# Altium schematic sheet A2-ESP.SchDoc
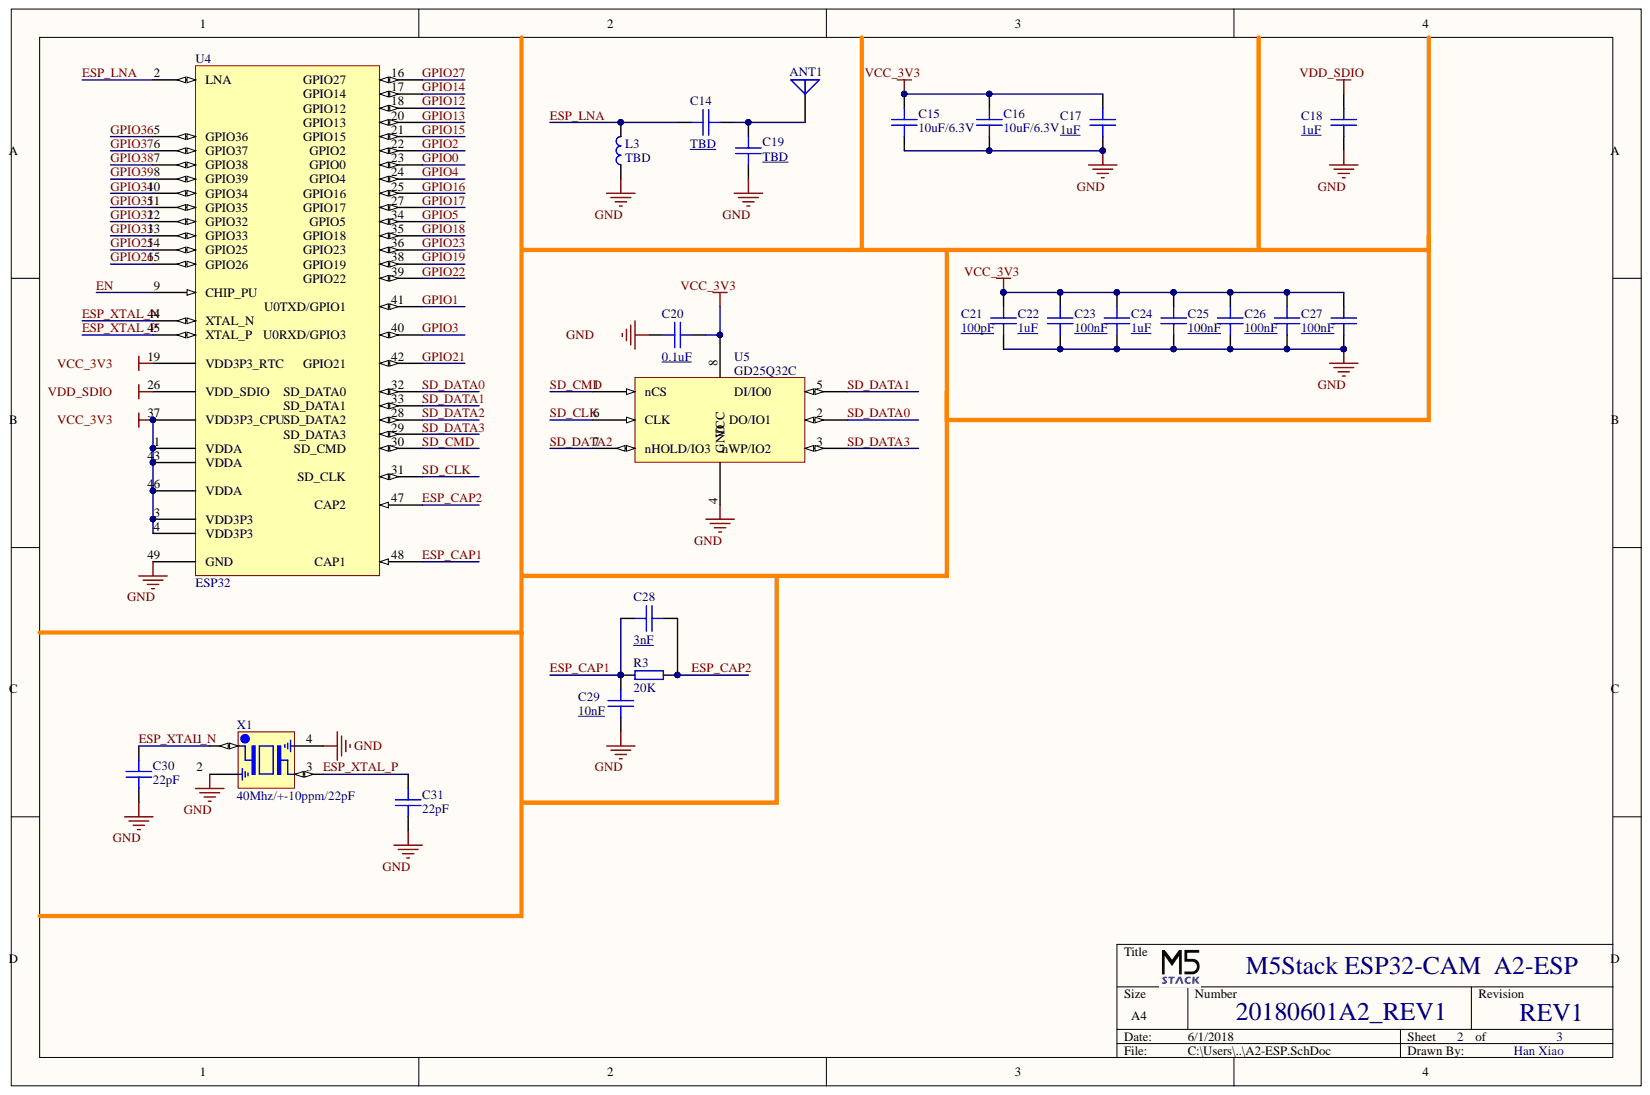
[diagram p0: A2-ESP.SchDoc]

## Parts
ANT1  Antenna  [Antenna_IIFA_2.4GHz_ESP]  (value not drawn)
C14  TBD  [SMT_C_0402]
C15  10uF/6.3V  [SMT_C_0603]
C16  10uF/6.3V  [SMT_C_0603]
C17  1uF  [SMT_C_0402]
C18  1uF  [SMT_C_0402]
C19  TBD  [SMT_C_0402]
C20  0.1uF  [SMT_C_0402]
C21  100pF  [SMT_C_0402]
C22  1uF  [SMT_C_0402]
C23  100nF  [SMT_C_0402]
C24  1uF  [SMT_C_0402]
C25  100nF  [SMT_C_0402]
C26  100nF  [SMT_C_0402]
C27  100nF  [SMT_C_0402]
C28  3nF  [SMT_C_0402]
C29  10nF  [SMT_C_0402]
C30  22pF  [SMT_C_0402]
C31  22pF  [SMT_C_0402]
L3  TBD  [SMT_L_0402]
R3  20K  [SMT_R_0402]
U4  ESP32  [PQFN40P600X600X90_HS-49N]
U5  GD25Q32C  [WSOP-8]
X1  40Mhz/+-10ppm/22pF  [SMT_XTAL_2520]

## Nets
GND: C15.2 C16.2 C17.1 C18.1 C19.1 C20.1 C21.1 C22.1 C23.1 C24.1 C25.1 C26.1 C27.1 C29.1 C30.2 C31.2 L3.2 U4.49(GND) U5.4(GND) X1.2 X1.4
VCC_3V3: C15.1 C16.1 C17.2 C20.2 C21.2 C22.2 C23.2 C24.2 C25.2 C26.2 C27.2 U4.1(VDDA) U4.3(VDD3P3) U4.4(VDD3P3) U4.19(VDD3P3_RTC) U4.37(VDD3P3_CPU) U4.43(VDDA) U4.46(VDDA) U5.8
VDD_SDIO: C18.2 U4.26(VDD_SDIO)
EN: U4.9(CHIP_PU)
ESP_CAP1: C28.1 C29.2 R3.1 U4.48
ESP_CAP2: C28.2 R3.2 U4.47
ESP_LNA: C14.1 L3.1 U4.2(LNA)
ESP_XTAL_N: C30.1 U4.44(XTAL_N) X1.1
ESP_XTAL_P: C31.1 U4.45(XTAL_P) X1.3
GPIO0: U4.23
GPIO1: U4.41
GPIO2: U4.22
GPIO3: U4.40
GPIO4: U4.24
GPIO5: U4.34
GPIO12: U4.18
GPIO13: U4.20
GPIO14: U4.17
GPIO15: U4.21
GPIO16: U4.25
GPIO17: U4.27
GPIO18: U4.35
GPIO19: U4.38
GPIO21: U4.42
GPIO22: U4.39
GPIO23: U4.36
GPIO25: U4.14(GPIO25)
GPIO26: U4.15(GPIO26)
GPIO27: U4.16
GPIO32: U4.12(GPIO32)
GPIO33: U4.13(GPIO33)
GPIO34: U4.10(GPIO34)
GPIO35: U4.11(GPIO35)
GPIO36: U4.5(GPIO36)
GPIO37: U4.6(GPIO37)
GPIO38: U4.7(GPIO38)
GPIO39: U4.8(GPIO39)
SD_CLK: U4.31 U5.6(CLK)
SD_CMD: U4.30 U5.1(nCS)
SD_DATA0: U4.32 U5.2
SD_DATA1: U4.33 U5.5
SD_DATA2: U4.28 U5.7(nHOLD/IO3)
SD_DATA3: U4.29 U5.3
NetANT1_1: ANT1.1 C14.2 C19.2

## Library data of the parts
ANT1 = Antenna: Generic Antenna; Component Kind: Standard; Library Name: Vowstar_Antenna.IntLib
C14 C17-C31 = SMT_C_0402: Surface mount capacitor 0402; Component Kind: Standard; Library Name: Vowstar_Basic_Device.IntLib
C15 C16 = SMT_C_0603: Surface mount capacitor 0603; Component Kind: Standard; Library Name: Vowstar_Basic_Device.IntLib
L3 = SMT_L_0402: Surface mount inductor 0402; Component Kind: Standard; Library Name: Vowstar_Basic_Device.IntLib
R3 = SMT_R_0402: Surface mount resistor 0402; Component Kind: Standard; Library Name: Vowstar_Basic_Device.IntLib
U4 = ESP32: ESP32 is designed for mobile, wearable electronics, and Internet of Things (IoT) applications. It has many features  of the state-of-the-art low power chips, including fine resolution clock gating, power modes, and dynamic power  scaling.; Component Kind: Standard; Library Name: Espressif-CHIP.SchLib
U5 = W25Qxx: Serial flash memory with dual and quad SPI; Component Kind: Standard; Library Name: Vowstar_IC_General.IntLib
X1 = SMT_XTAL_PASSIVE: Passive crystal; Component Kind: Standard; Library Name: Vowstar_Basic_Device.IntLib
